# Altium schematic sheet power.SchDoc(U_power)
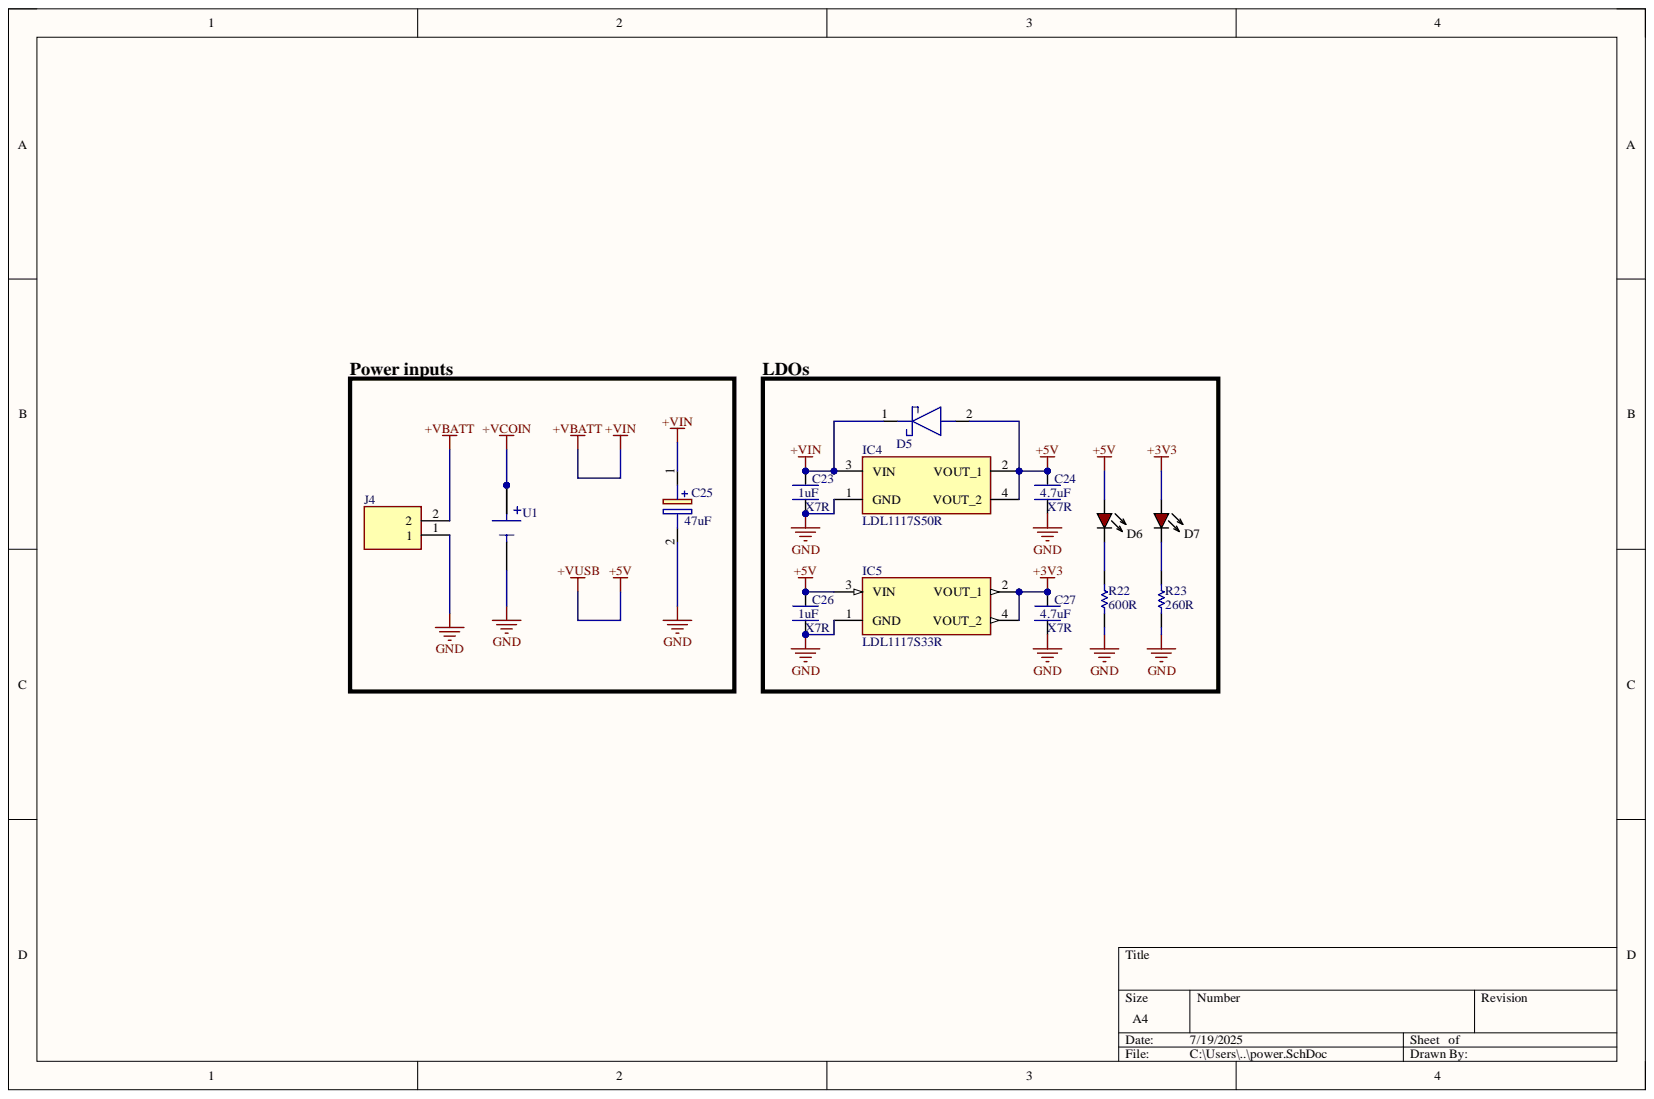
[diagram p0: power.SchDoc]

## Parts
C23  1uF  Dielectric: X7R  [CAP_1206]
C24  4.7uF  Dielectric: X7R  [CAP_1206]
C25  47uF  [CAPAE660X550N]
C26  1uF  Dielectric: X7R  [CAP_1206]
C27  4.7uF  Dielectric: X7R  [CAP_1206]
D5  CUS10S30,H3F  [1SS357TPH3F]  (value not drawn)
D6  red  [LED_0603]  (value not drawn)
D7  red  [LED_0603]  (value not drawn)
IC4  LDL1117S50R  [SOT230P700X180-4N]
IC5  LDL1117S33R  [SOT230P700X180-4N]
J4  EBBA-02-C-SS-BU  [EBBA02CSSBU]  (value not drawn)
R22  600R  [RES_0603]
R23  260R  [RES_0603]
U1  BAT-HLD-012-SMT  [BATHLD012SMT]  (value not drawn)

## Nets
+3V3: C27.2 D7.1 IC5.2(VOUT_1) IC5.4(VOUT_2)
+5V: C24.2 C26.2 D5.2 D6.1 IC4.2(VOUT_1) IC4.4(VOUT_2) IC5.3(VIN)
+VBATT: C23.2 C25.1 D5.1 IC4.3(VIN) J4.2
+VCOIN: U1.P1 U1.P2
GND: C23.1 C24.1 C25.2 C26.1 C27.1 IC4.1(GND) IC5.1(GND) J4.1 R22.1 R23.1 U1.N
NetD6_2: D6.2 R22.2
NetD7_2: D7.2 R23.2

## Library data of the parts
C23 C24 C26 C27 = CAP_1206: Capacitor SMD 1206 generic; Component Kind: Standard; Library Name: myLib.SchLib
  C23 C26: Value: 1uF
  C24 C27: Value: 4.7uF
C25 = 865080543009: 865080543009  Capacitor Polarised; Manufacturer_Name: Wurth Elektronik; Component Kind: Standard; Datasheet Link: https://katalog.we-online.de/pbs/datasheet/865080543009.pdf; Height: 5.5mm; Manufacturer_Part_Number: 865080543009; Mouser Part Number: 710-865080543009; Mouser Price/Stock: https://www.mouser.co.uk/ProductDetail/Wurth-Elektronik/865080543009?qs=0KOYDY2FL2%2F%252BYmhMLtEhDQ%3D%3D
D5 = CUS10S30,H3F: Schottky Diode; Manufacturer_Name: Toshiba; Arrow Part Number: CUS10S30,H3F; Arrow Price/Stock: https://www.arrow.com/en/products/cus10s30h3f/toshiba?region=nac; Component Kind: Standard; Datasheet Link: http://toshiba.semicon-storage.com/info/docget.jsp?did=14077&prodName=CUS10S30; Height: 1mm; Library Name: SamacSys.SchLib; Manufacturer_Part_Number: CUS10S30,H3F; Mouser Part Number: 757-CUS10S30H3F; Mouser Price/Stock: https://www.mouser.co.uk/ProductDetail/Toshiba/CUS10S30H3F?qs=cW4DzVrAanO4Xm6ySrHr7g%3D%3D
D6 D7 = LED_0603: LED SMD 0603 generic; Current (mA): 5mA; Forward voltage: 2.1; Color: red; Component Kind: Standard; ComponentLink1Description: New link; ComponentLink1URL: https://www.digikey.com.au/en/products/detail/liteon/LTST-C190GKT/269230; Library Name: myLib.SchLib
IC4 = LDL1117S50R: Integrated Circuit; Manufacturer_Name: STMicroelectronics; Arrow Part Number: LDL1117S50R; Arrow Price/Stock: https://www.arrow.com/en/products/ldl1117s50r/stmicroelectronics?utm_currency=USD&region=europe; Component Kind: Standard; Datasheet Link: http://www.farnell.com/datasheets/2259188.pdf; Height: 1.8mm; Library Name: SamacSys.SchLib; Manufacturer_Part_Number: LDL1117S50R; Mouser Part Number: 511-LDL1117S50R; Mouser Price/Stock: https://www.mouser.co.uk/ProductDetail/STMicroelectronics/LDL1117S50R?qs=AQlKX63v8RsuN9BQFiVf%2Fg%3D%3D
IC5 = LDL1117S33R: Integrated Circuit; Manufacturer_Name: STMicroelectronics; Arrow Part Number: LDL1117S33R; Arrow Price/Stock: https://www.arrow.com/en/products/ldl1117s33r/stmicroelectronics?utm_currency=USD&region=europe; Component Kind: Standard; Datasheet Link: https://componentsearchengine.com/Datasheets/2/LDL1117S33R.pdf; Height: 1.8mm; Library Name: SamacSys.SchLib; Manufacturer_Part_Number: LDL1117S33R; Mouser Part Number: 511-LDL1117S33R; Mouser Price/Stock: https://www.mouser.co.uk/ProductDetail/STMicroelectronics/LDL1117S33R?qs=AQlKX63v8Rt9Bf6AWSrbFg%3D%3D
J4 = EBBA-02-C-SS-BU: Connector; Manufacturer_Name: Adam Tech; Component Kind: Standard; Datasheet Link: https://datasheet.datasheetarchive.com/originals/distributors/Datasheets_SAMA/2da73933fd867bb01aba3cef730a29a2.pdf; Height: 10.5156mm; Manufacturer_Part_Number: EBBA-02-C-SS-BU; Mouser Part Number: 737-EBBA-02-C-SS-BU; Mouser Price/Stock: https://www.mouser.co.uk/ProductDetail/Adam-Tech/EBBA-02-C-SS-BU?qs=HoCaDK9Nz5co7sCXiB6R%252Bw%3D%3D
R22 R23 = RES_0603: resistor SMD 0603 generic; Component Kind: Standard; Library Name: myLib.SchLib
  R22: value: 600R
  R23: value: 260R
U1 = BAT-HLD-012-SMT: Undefined or Miscellaneous; Manufacturer_Name: TE Connectivity; Arrow Part Number: BAT-HLD-012-SMT; Arrow Price/Stock: https://www.arrow.com/en/products/bat-hld-012-smt/te-connectivity?utm_currency=USD&region=nac; Component Kind: Standard; Datasheet Link: https://linxtechnologies.com/wp/wp-content/uploads/bat-hld-012-smt.pdf; Height: 3mm; Library Name: SamacSys.SchLib; Manufacturer_Part_Number: BAT-HLD-012-SMT; Mouser Part Number: 712-BAT-HLD-012-SMT; Mouser Price/Stock: https://www.mouser.co.uk/ProductDetail/TE-Connectivity-Linx-Technologies/BAT-HLD-012-SMT?qs=Z0V%2FpEl%252BOGfZsSJil9WIXw%3D%3D
